# Altium schematic sheet POWER_ISOLATE_AND_LDO.SchDoc
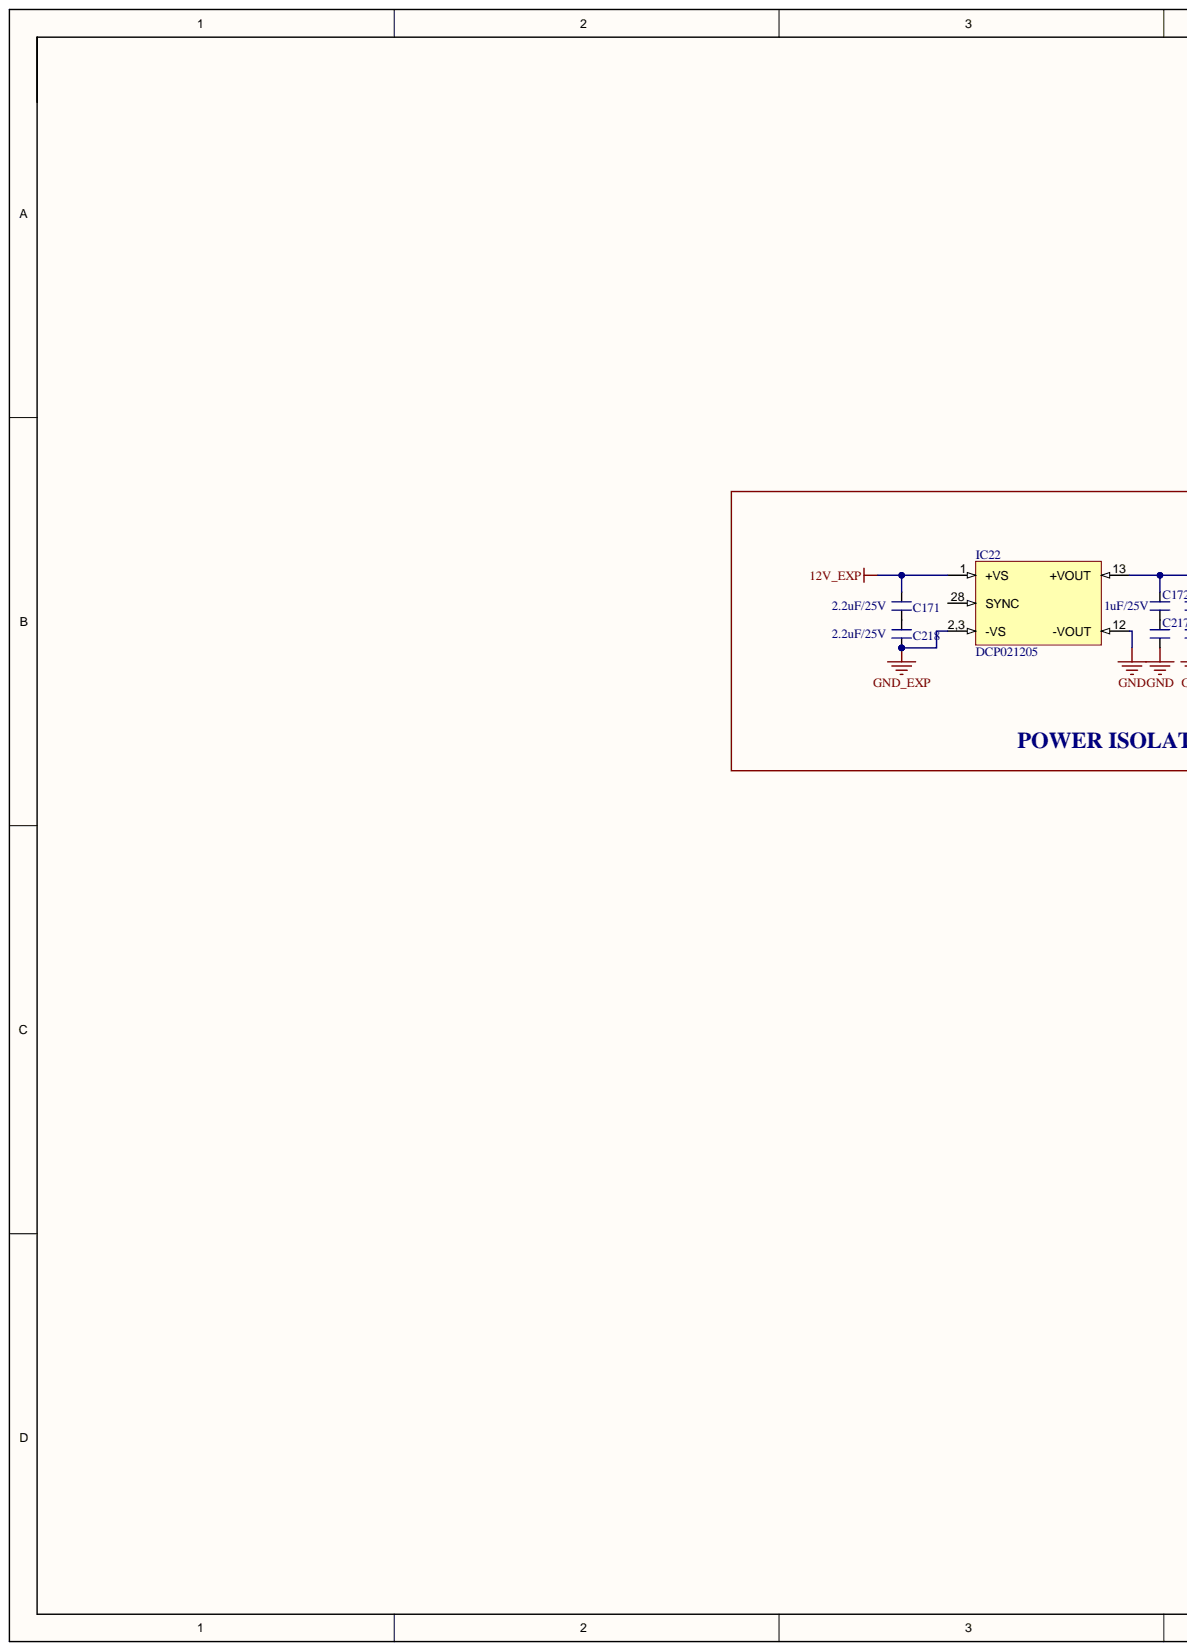
[diagram p0: POWER_ISOLATE_AND_LDO.SchDoc, left]
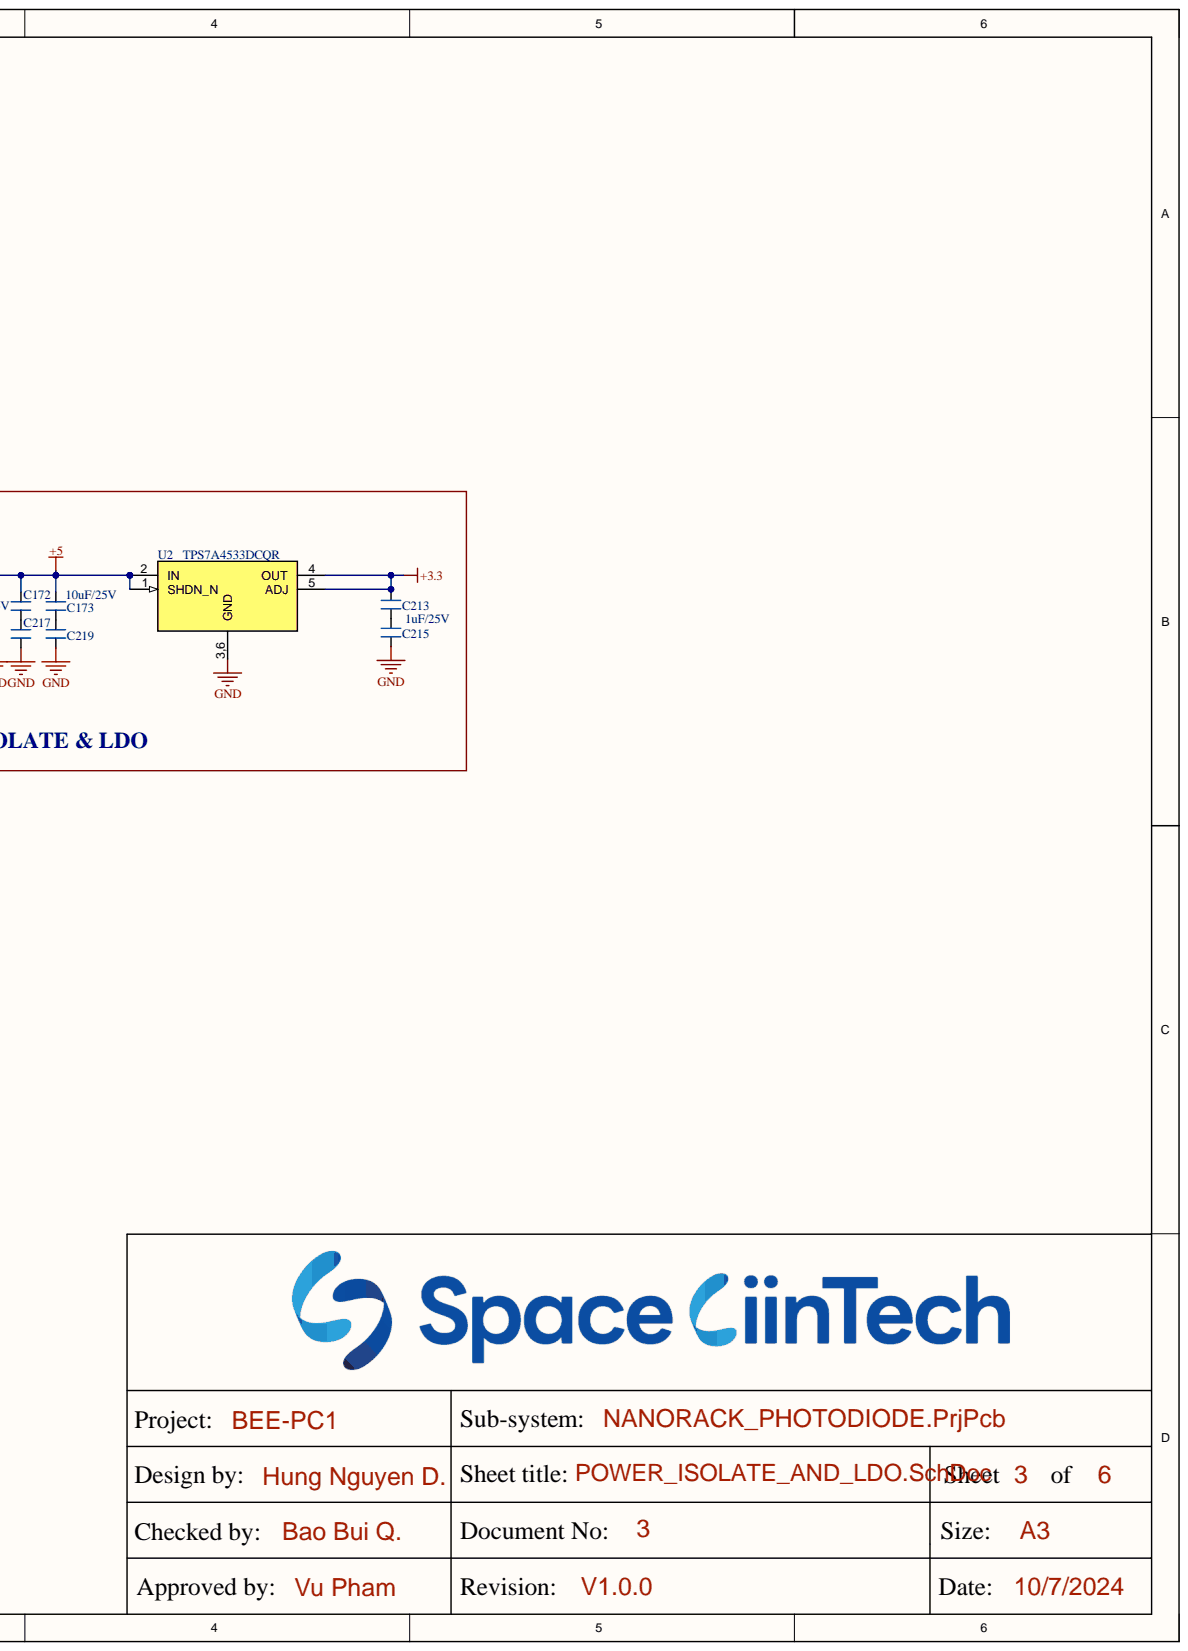
[diagram p1: POWER_ISOLATE_AND_LDO.SchDoc, right]

## Parts
C171  2.2uF/25V  [SM-0603]
C172  1uF/25V  [SM-0603]
C173  10uF/25V  [SM-0603]
C213  1uF/25V  [SM-0603]
C215  1uF/25V  [SM-0603]
C217  1uF/25V  [SM-0603]
C218  2.2uF/25V  [SM-0603]
C219  10uF/25V  [SM-0603]
IC22  DCP021205  [DCP02]
U2  TPS7A4533DCQR  [SOT223-6]

## Nets
12V_EXP: C171.2 IC22.1(+VS)
+3.3: C213.2 U2.4(OUT) U2.5(ADJ)
+5: C172.2 C173.2 IC22.13(+VOUT) U2.1(SHDN_N) U2.2(IN)
GND: C215.1 C217.1 C219.1 IC22.12(-VOUT) U2.3,6(GND)
GND_EXP: C218.1 IC22.2,3(-VS)
NetC171_1: C171.1 C218.2
NetC172_1: C172.1 C217.2
NetC173_1: C173.1 C219.2
NetC213_1: C213.1 C215.2

Not connected: IC22 28(SYNC)

## Library data of the parts
C171-C173 C213 C215 C217-C219 = CM: 0603; Value: 103; Component Kind: Standard; Library Name: Capacitors.SchLib
IC22 = PWR_ISO_DCP02: Component Kind: Standard; Library Name: Library.SchLib
U2 = TPS7A4533DCQR: IC REG LINEAR 3.3V 1.5A SOT223-6; Component Kind: Standard; Library Name: Library.SchLib
